# Altium schematic sheet Speaker Control.SchDoc
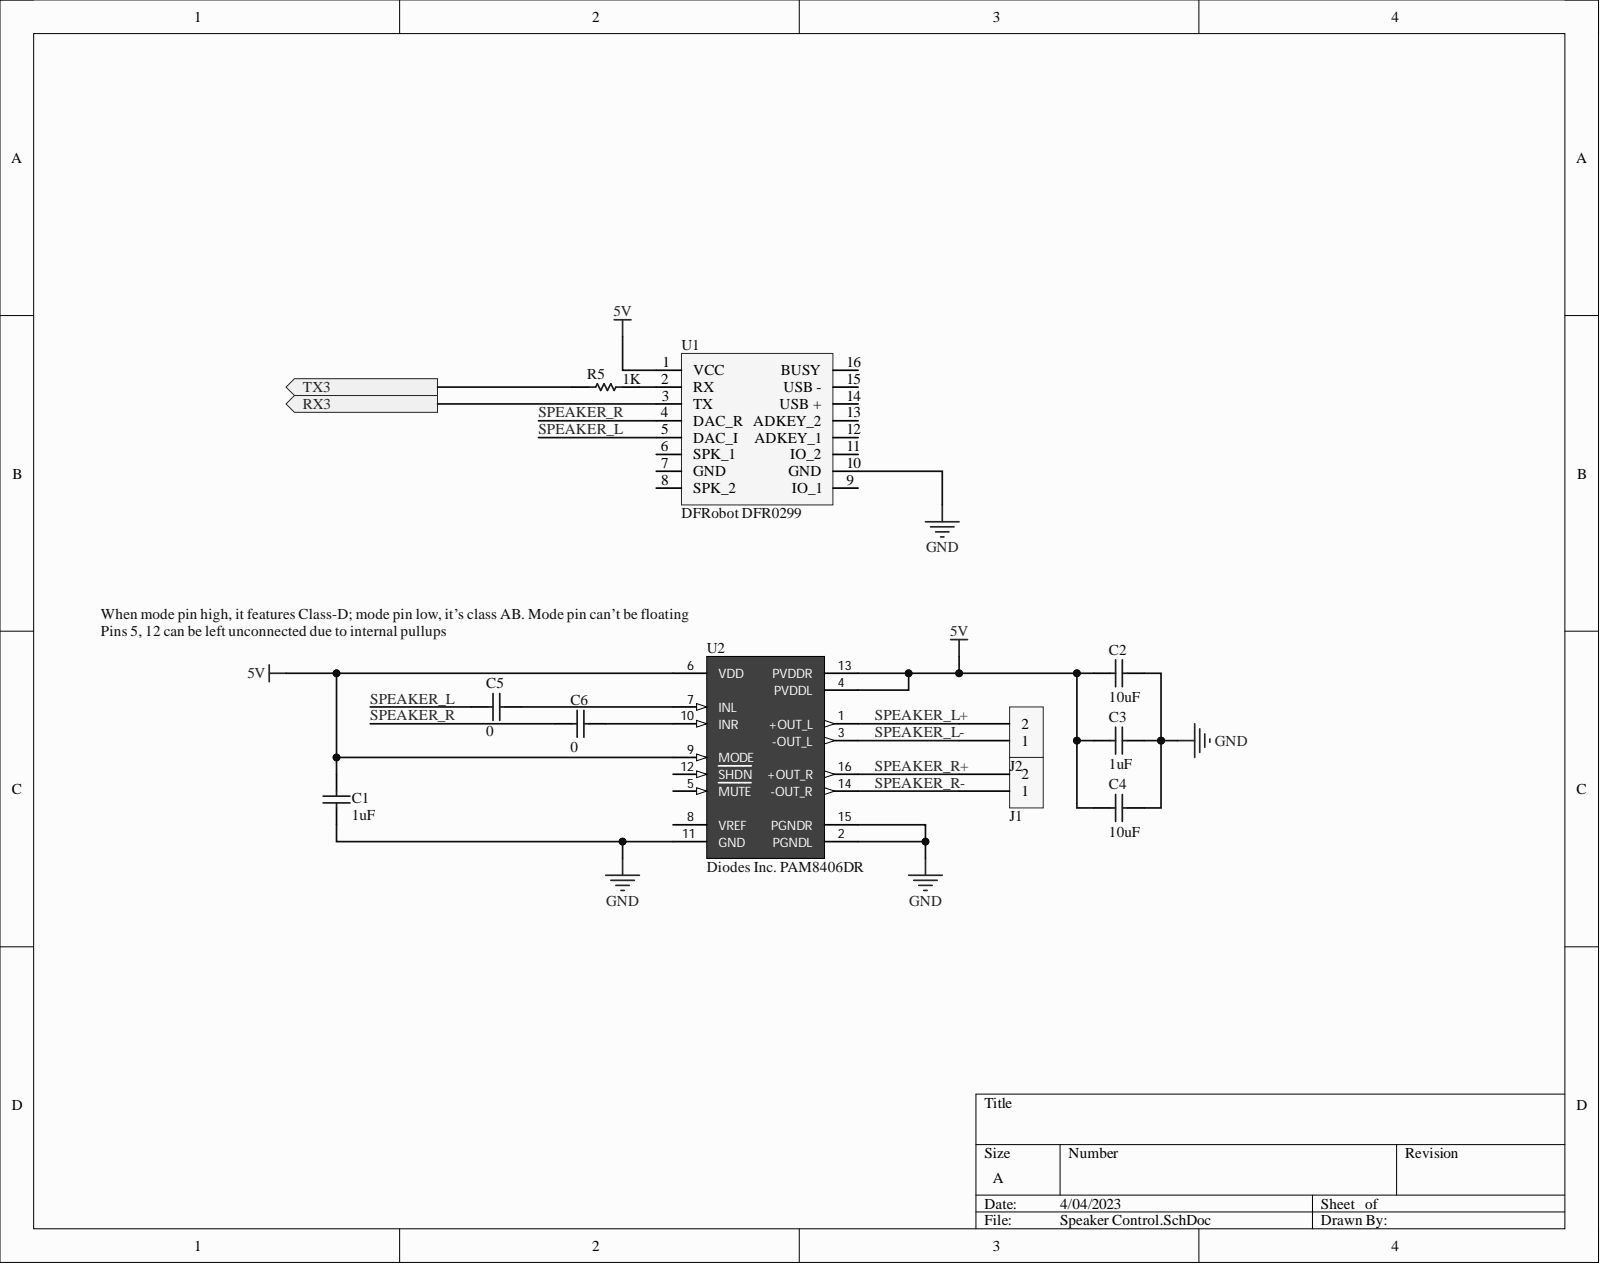
[diagram p0: Speaker Control.SchDoc]

## Parts
C1  Capacitance: 1uF  [CAPC2013X94X50NL20T25]  (hidden comment: AVX Interconnect / Elco 08051C182KAT2A)
C2  Capacitance: 10uF  [CAPC2013X94X50NL20T25]  (hidden comment: AVX Interconnect / Elco 08051C182KAT2A)
C3  Capacitance: 1uF  [CAPC2013X94X50NL20T25]  (hidden comment: AVX Interconnect / Elco 08051C182KAT2A)
C4  Capacitance: 10uF  [CAPC2013X94X50NL20T25]  (hidden comment: AVX Interconnect / Elco 08051C182KAT2A)
C5  AVX Interconnect / Elco 08051C182KAT2A  [CAPC2013X94X50NL20T25]  (value not drawn)
C6  AVX Interconnect / Elco 08051C182KAT2A  [CAPC2013X94X50NL20T25]  (value not drawn)
J1  Header 2 POS 2.54mm  [Header 2 POS 2.54mm-Footprint-1]  (value not drawn)
J2  Header 2 POS 2.54mm  [Header 2 POS 2.54mm-Footprint-1]  (value not drawn)
R5  Resistance: 1K  [RESC2013X70X40ML20T20]  (hidden comment: Panasonic ERJ-6GEYJ911V)
U1  DFRobot DFR0299  [PCB-k35jh420uk3dvlqx7577-1]
U2  Diodes Inc. PAM8406DR  Manufacturer Part Number: PAM8406DR  [PCB-gk86xqggypy5wuv4hio8-1]

## Ports
RX3, TX3

## Nets
5V: C1.1 C2.1 C3.1 C4.1 U1.1(VCC) U2.4(PVDDL) U2.6(VDD) U2.9(MODE) U2.13(PVDDR)
GND: C1.2 C2.2 C3.2 C4.2 U1.10(GND) U2.2(PGNDL) U2.11(GND) U2.15(PGNDR)
RX3: U1.3(TX) ; port RX3
SPEAKER_L: C5.1 U1.5(DAC_I)
SPEAKER_L+: J2.2 U2.1(+OUT_L)
SPEAKER_L-: J2.1 U2.3(-OUT_L)
SPEAKER_R: C6.1 U1.4(DAC_R)
SPEAKER_R+: J1.2 U2.16(+OUT_R)
SPEAKER_R-: J1.1 U2.14(-OUT_R)
TX3: R5.1 ; port TX3
NetC5_2: C5.2 U2.7(INL)
NetC6_2: C6.2 U2.10(INR)
NetR5_2: R5.2 U1.2(RX)

Not connected: U1 6(SPK_1) 7(GND) 8(SPK_2) 9(IO_1) 11(IO_2) 12(ADKEY_1) 13(ADKEY_2) 14(USB_+) 15(USB_-) 16(BUSY); U2 5(MUTE) 8(VREF) 12(SHDN)

## Library data of the parts
C1-C4 = CMP-128428-2: CAP CER 1800PF 100V 10% X7R 0805; Case/Package (SI): 2012; Lead-Free Status: Lead Free; Voltage Rating (DC): 100.0 V; Capacitance Tolerance: ±10%; Case/Package: 0805; Component Kind: Standard; Dielectric Characteristic: X7R; Library Name: My Personal Space; Manufacturer Part Number: 08051C182KAT2A; Number of Pins: 2; Octopart Url: https://octopart.com/08051c182kat2a-avx+interconnect+%2F+elco-2160389; OctopartID: 0d2cc406df4fd2eb; Packaging: Tape & Reel (TR); RoHS: Compliant
C5 C6 = CMP-128428-2: CAP CER 1800PF 100V 10% X7R 0805; Capacitance: 0; Case/Package (SI): 2012; Lead-Free Status: Lead Free; Voltage Rating (DC): 100.0 V; Capacitance Tolerance: ±10%; Case/Package: 0805; Component Kind: Standard; Dielectric Characteristic: X7R; Library Name: My Personal Space; Manufacturer Part Number: 08051C182KAT2A; Number of Pins: 2; Octopart Url: https://octopart.com/08051c182kat2a-avx+interconnect+%2F+elco-2160389; OctopartID: 0d2cc406df4fd2eb; Packaging: Tape & Reel (TR); RoHS: Compliant
J1 J2 = CMP-5eamy876imudr0jkxums-1: Header 2 Position 2x1, 2.54mm; Component Kind: Standard; CustomComponentID: 5eamy876imudr0jkxums; Library Name: My Personal Space
R5 = CMP-3670835-2: Thick Film Resistors - SMD 0805 910ohms 5% Tol; Case/Package (SI): 2012; Lead-Free Status: Lead Free; Power Rating: 0.125 W; Voltage Rating (DC): 150.0 V; Case/Package: 0805; Component Kind: Standard; Library Name: My Personal Space; Lifecycle Status: Not Listed by Manufacturer; Manufacturer Part Number: ERJ-6GEYJ911V; Mounting Style: Surface Mount; Octopart Url: https://octopart.com/erj-6geyj911v-panasonic-55423073; OctopartID: 40418a06d5469f86; Packaging: Cut Tape (CT); REACH SVHC Compliance: No SVHC; Resistance Tolerance: ±5%; RoHS: Compliant; Size-Height: 0.0006 m; Size-Length: 0.002 m; Size-Width: 0.00125 m
U1 = CMP-b7e97a40b4f6682e-7: Module; MP3; microSD; Revised footprint; Component Kind: Standard; Library Name: My Personal Space
U2 = CMP-56759ec58b4989b2-2: Dual Channel 5 W 2 O Alternative Class D / AB Stereo Audio Amplifier - SOIC-16; Lead-Free Status: Lead Free; Component Kind: Standard; Library Name: My Personal Space; Lifecycle Status: Active; Octopart URL: http://octopart.com/pam8406dr-diodes+inc.-29030490; OctopartID: 56759ec58b4989b2; RoHS: Compliant
